# Altium schematic sheet JacdacBreadboardRingsWasherG 109.SchDoc
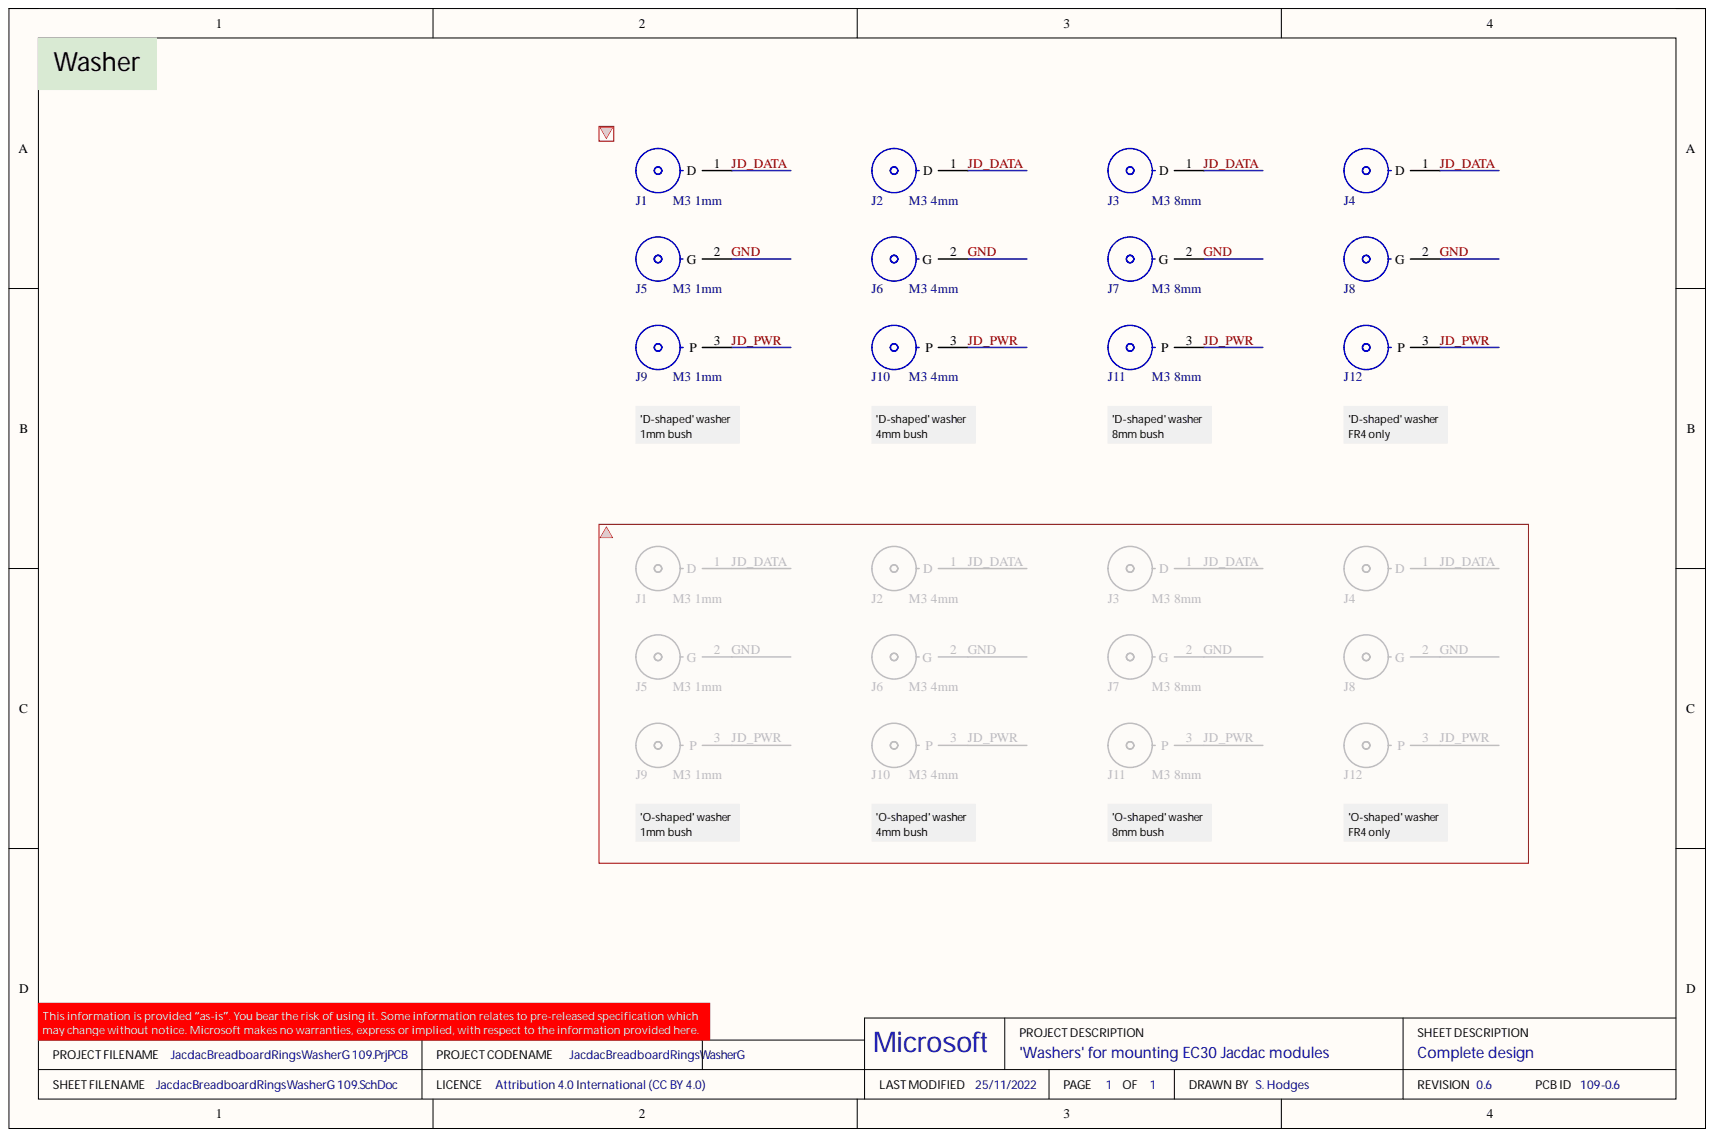
[diagram p0: JacdacBreadboardRingsWasherG 109.SchDoc]

## Parts
J1  Diameter: M3  Standoff Height: 1mm
J2  Diameter: M3  Standoff Height: 4mm
J3  Diameter: M3  Standoff Height: 8mm
J4  (no value)
J5  Diameter: M3  Standoff Height: 1mm
J6  Diameter: M3  Standoff Height: 4mm
J7  Diameter: M3  Standoff Height: 8mm
J8  (no value)
J9  Diameter: M3  Standoff Height: 1mm
J10  Diameter: M3  Standoff Height: 4mm
J11  Diameter: M3  Standoff Height: 8mm
J12  (no value)

## Nets
GND: J5.2 J6.2 J7.2 J8.2
JD_DATA: J1.1 J2.1 J3.1 J4.1
JD_PWR: J9.3 J10.3 J11.3 J12.3

## Library data of the parts
J1 = (no symbol name): Jacdac EC30 1mm-bush-washer JD_DATA; Supplier 1: LCSC; Supplier Part Number 1: C2916331
J2 = (no symbol name): Jacdac EC30 4mm-bush-washer JD_DATA; Supplier 1: LCSC; Supplier 2: LCSC; Supplier Part Number 1: C2915635; Supplier Part Number 2: C2916382
J3 = (no symbol name): Jacdac EC30 8mm-bush-washer JD_DATA; Supplier 1: LCSC; Supplier 2: LCSC; Supplier Part Number 1: C2916369; Supplier Part Number 2: C2916368
J4 J8 J12 = (no symbol name): Hole Size: M3
J5 = (no symbol name): Jacdac EC30 1mm-bush-washer GND; Supplier 1: LCSC; Supplier Part Number 1: C2916331
J6 = (no symbol name): Jacdac EC30 4mm-bush-washer GND; Supplier 1: LCSC; Supplier 2: LCSC; Supplier Part Number 1: C2915635; Supplier Part Number 2: C2916382
J7 = (no symbol name): Jacdac EC30 8mm-bush-washer GND; Supplier 1: LCSC; Supplier 2: LCSC; Supplier Part Number 1: C2916369; Supplier Part Number 2: C2916368
J9 = (no symbol name): Jacdac EC30 1mm-bush-washer JD_PWR; Supplier 1: LCSC; Supplier Part Number 1: C2916331
J10 = (no symbol name): Jacdac EC30 4mm-bush-washer JD_PWR; Supplier 1: LCSC; Supplier 2: LCSC; Supplier Part Number 1: C2915635; Supplier Part Number 2: C2916382
J11 = (no symbol name): Jacdac EC30 8mm-bush-washer JD_PWR; Supplier 1: LCSC; Supplier 2: LCSC; Supplier Part Number 1: C2916369; Supplier Part Number 2: C2916368
